# Altium schematic sheet TEMP_CTRL.SchDoc
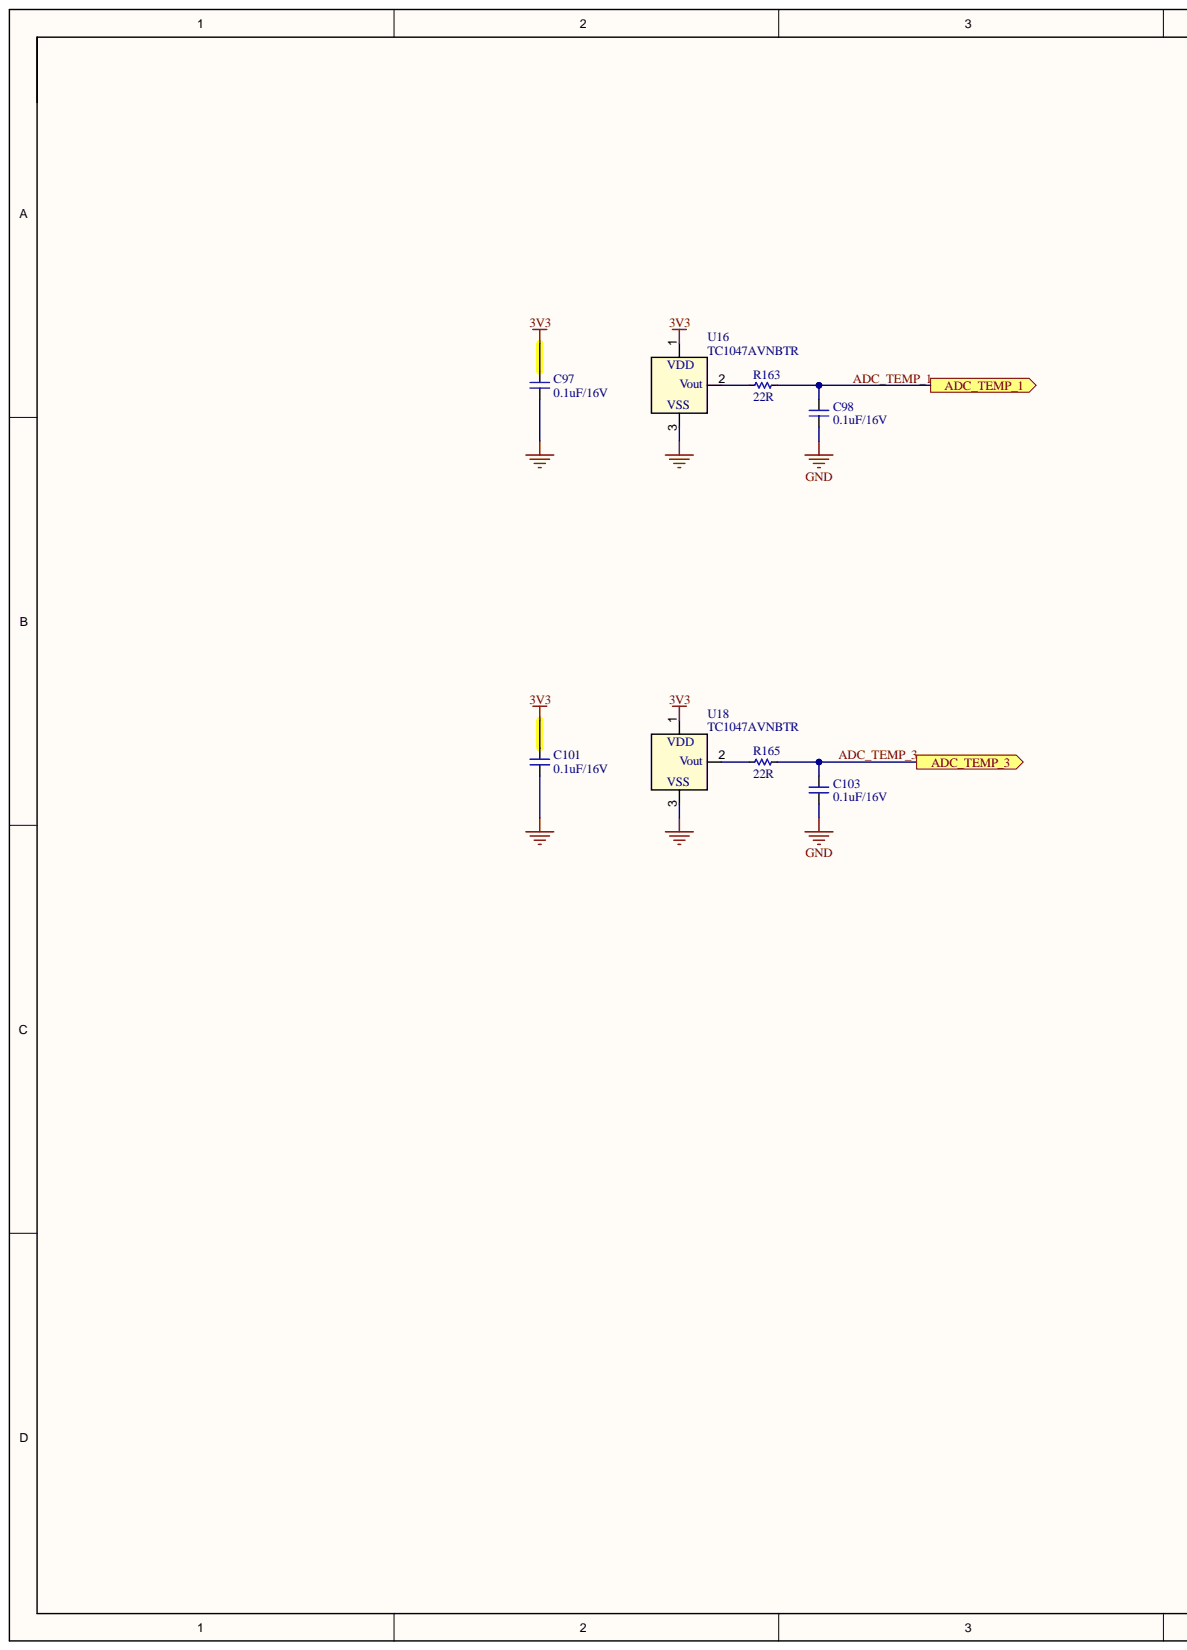
[diagram p0: TEMP_CTRL.SchDoc, left]
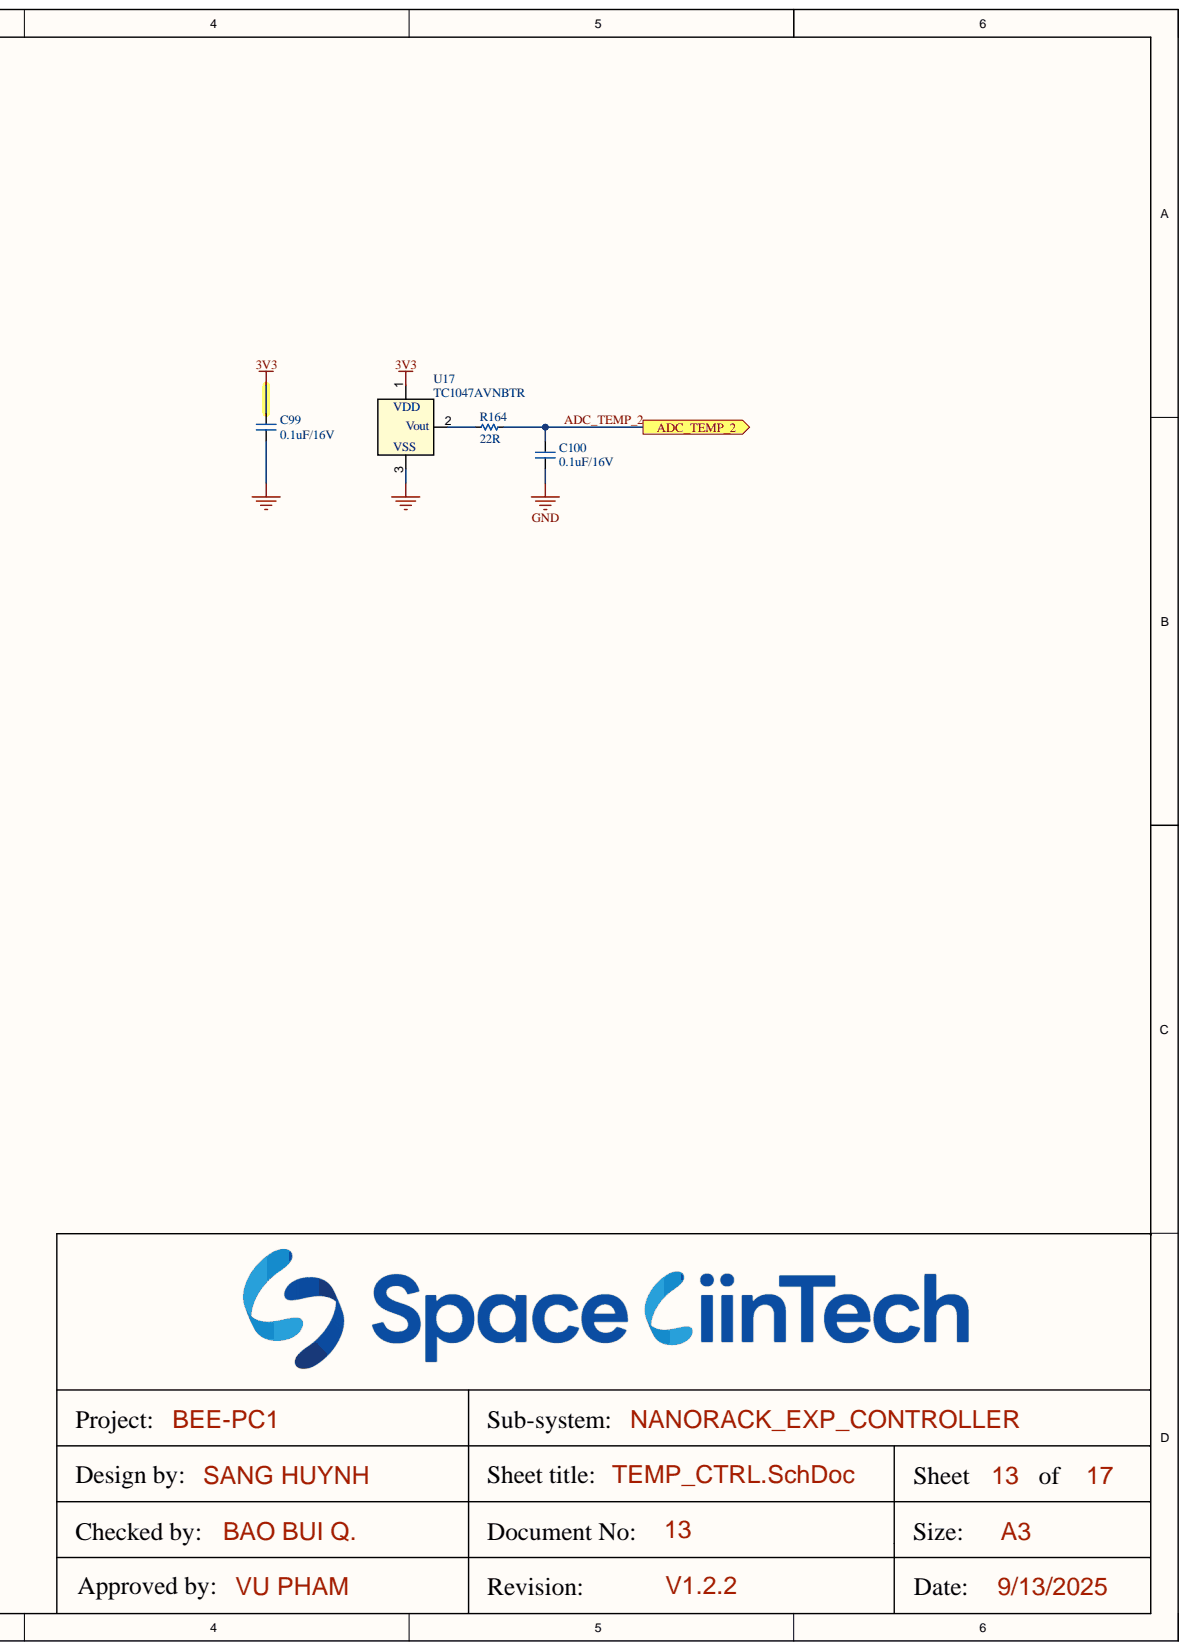
[diagram p1: TEMP_CTRL.SchDoc, right]

## Parts
C97  0.1uF/16V  [CAP_C_1608X80]
C98  0.1uF/16V  [CAP_C_1608X80]
C99  0.1uF/16V  [CAP_C_1608X80]
C100  0.1uF/16V  [CAP_C_1608X80]
C101  0.1uF/16V  [CAP_C_1608X80]
C103  0.1uF/16V  [CAP_C_1608X80]
R163  22R  [RES_C_1608X60]
R164  22R  [RES_C_1608X60]
R165  22R  [RES_C_1608X60]
U16  TC1047AVNBTR  [SOT-23_MC_MCH]
U17  TC1047AVNBTR  [SOT-23_MC_MCH]
U18  TC1047AVNBTR  [SOT-23_MC_MCH]

## Ports
ADC_TEMP_1, ADC_TEMP_2, ADC_TEMP_3

## Nets
3V3: C97.2 C99.2 C101.2 U16.1 U17.1 U18.1
GND: C97.1 C98.1 C99.1 C100.1 C101.1 C103.1 U16.3 U17.3 U18.3
ADC_TEMP_1: C98.2 R163.2 ; port ADC_TEMP_1
ADC_TEMP_2: C100.2 R164.2 ; port ADC_TEMP_2
ADC_TEMP_3: C103.2 R165.2 ; port ADC_TEMP_3
NetR163_1: R163.1 U16.2
NetR164_1: R164.1 U17.2
NetR165_1: R165.1 U18.2

## Library data of the parts
C97-C101 C103 = CAP_SMD: CAP_Chip; Value: 33pF; Component Kind: Standard; Library Name: LABLIB.SchLib
R163-R165 = _RES_B_2: RES; Component Kind: Standard; Library Name: LABLIB.SchLib
U16-U18 = TC1047AVNBTR: No Description Available; Manufacturer_Name: Microchip; Component Kind: Standard; Manufacturer_Part_Number: TC1047AVNBTR; RefDes: RefDes; Type: Type; Copyright: Copyright (C) 2025 Ultra Librarian. All rights reserved.; Library Name: COMM_SCHLIB.SchLib
